# Altium schematic sheet 02_CONNECTOR.SchDoc
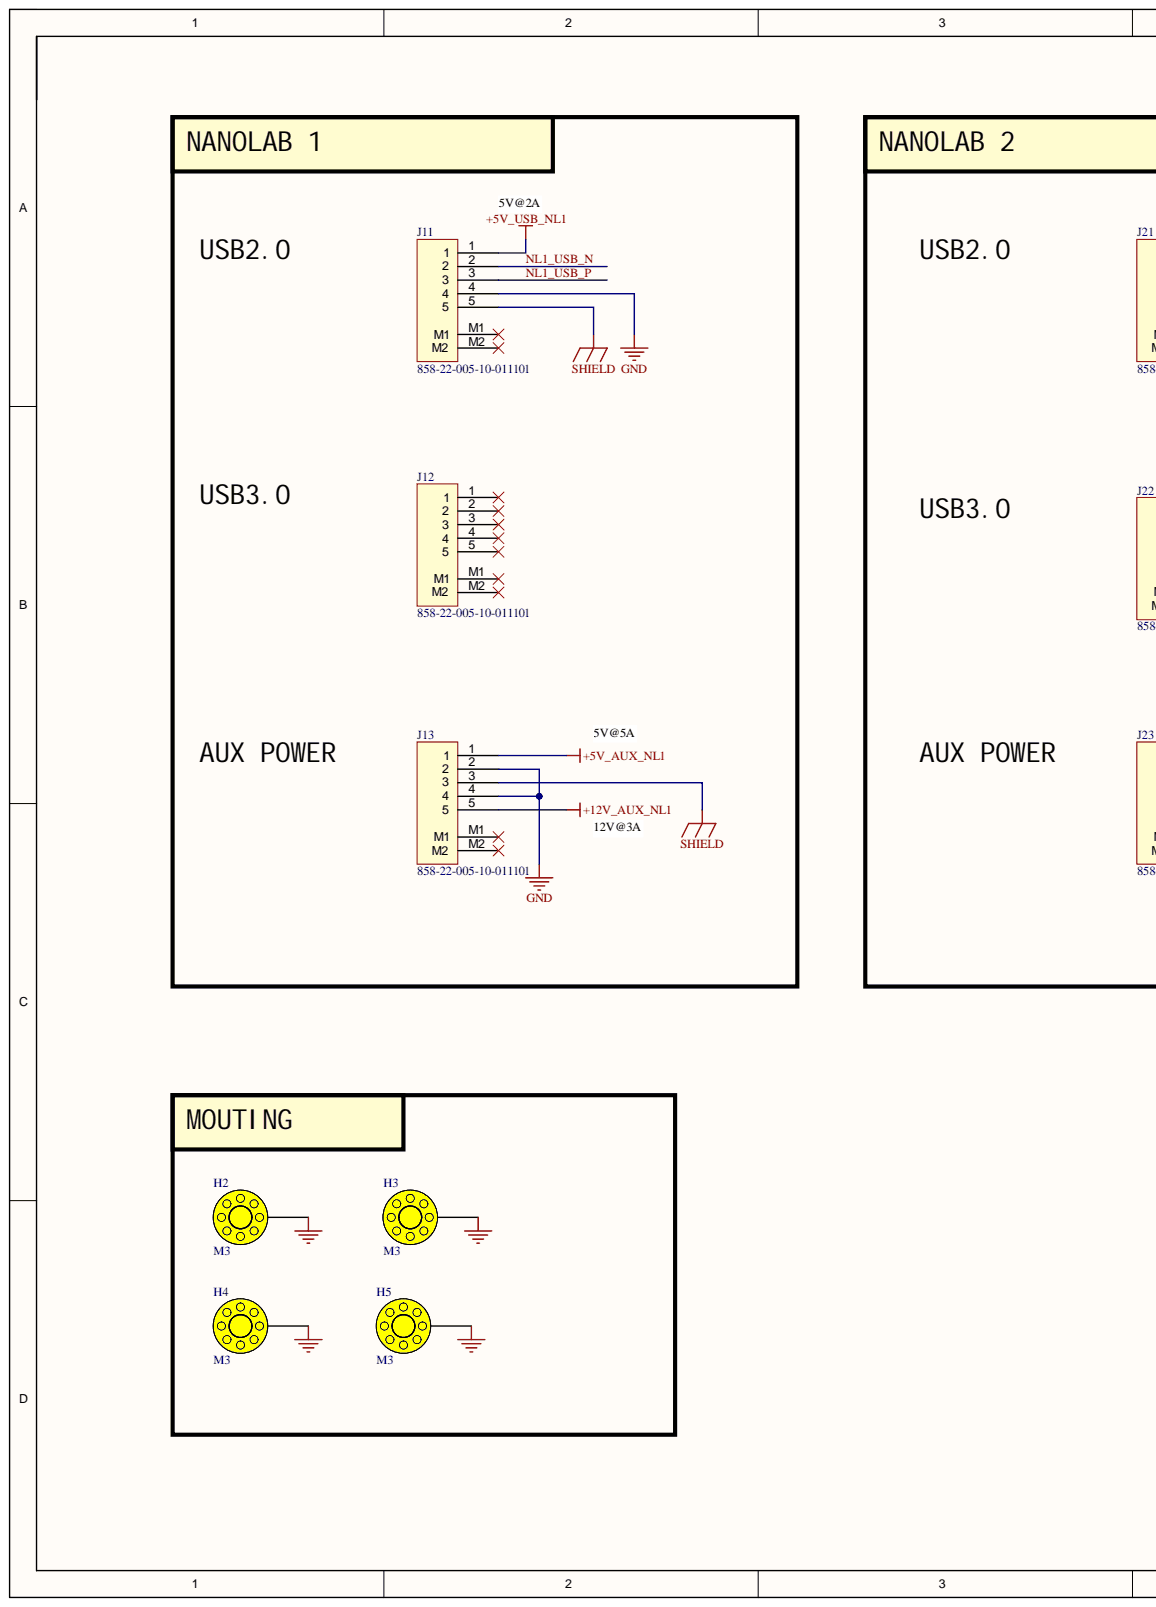
[diagram p0: 02_CONNECTOR.SchDoc, left]
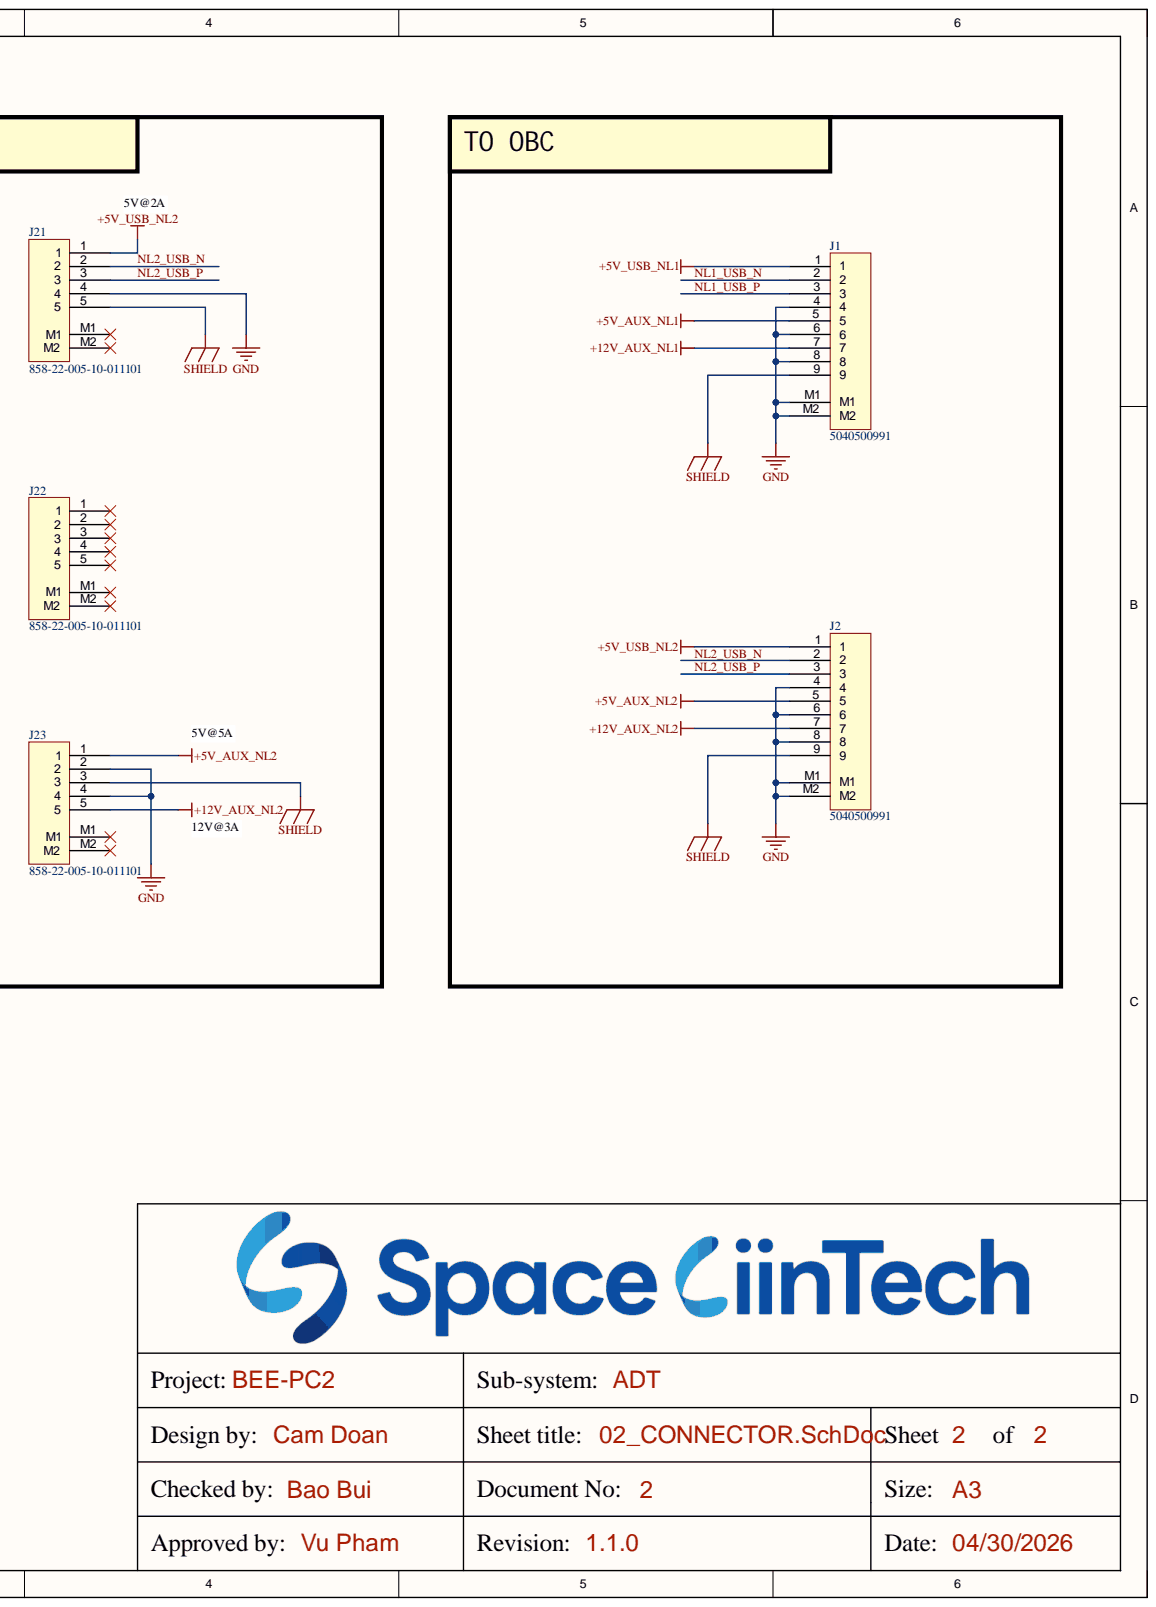
[diagram p1: 02_CONNECTOR.SchDoc, right]

## Parts
H2  M3  [HEX-M3_NoVia]
H3  M3  [HEX-M3_NoVia]
H4  M3  [HEX-M3_NoVia]
H5  M3  [HEX-M3_NoVia]
J1  5040500991
J2  5040500991
J11  858-22-005-10-011101  [Mill-Max_858-22-005-10-011101]
J12  858-22-005-10-011101  [Mill-Max_858-22-005-10-011101]
J13  858-22-005-10-011101  [Mill-Max_858-22-005-10-011101]
J21  858-22-005-10-011101  [Mill-Max_858-22-005-10-011101]
J22  858-22-005-10-011101  [Mill-Max_858-22-005-10-011101]
J23  858-22-005-10-011101  [Mill-Max_858-22-005-10-011101]

## Nets
+5V_AUX_NL1: J1.5 J13.1
+5V_AUX_NL2: J2.5 J23.1
+5V_USB_NL1: J1.1 J11.1
+5V_USB_NL2: J2.1 J21.1
+12V_AUX_NL1: J1.7 J13.5
+12V_AUX_NL2: J2.7 J23.5
GND: H2.1 H3.1 H4.1 H5.1 J1.4 J1.6 J1.8 J1.M1 J1.M2 J2.4 J2.6 J2.8 J2.M1 J2.M2 J11.4 J13.2 J13.4 J21.4 J23.2 J23.4
SHIELD: J1.9 J2.9 J11.5 J13.3 J21.5 J23.3
NL1_USB_N: J1.2 J11.2
NL1_USB_P: J1.3 J11.3
NL2_USB_N: J2.2 J21.2
NL2_USB_P: J2.3 J21.3

Not connected: J11 M1 M2; J12 1 2 3 4 5 M1 M2; J13 M1 M2; J21 M1 M2; J22 1 2 3 4 5 M1 M2; J23 M1 M2

## Library data of the parts
H2-H5 = HEX-M3: Component Kind: Standard; Library Name: hex_M3.IntLib
J1 J2 = Header 9x1_2M: Component Kind: Standard; Library Name: LABLIB.SchLib
J11-J13 J21-J23 = Header 5x1_2M: Component Kind: Standard; Library Name: LABLIB.SchLib
